# Altium schematic sheet 3. Latching Relays.SchDoc("3. Latching Relays")
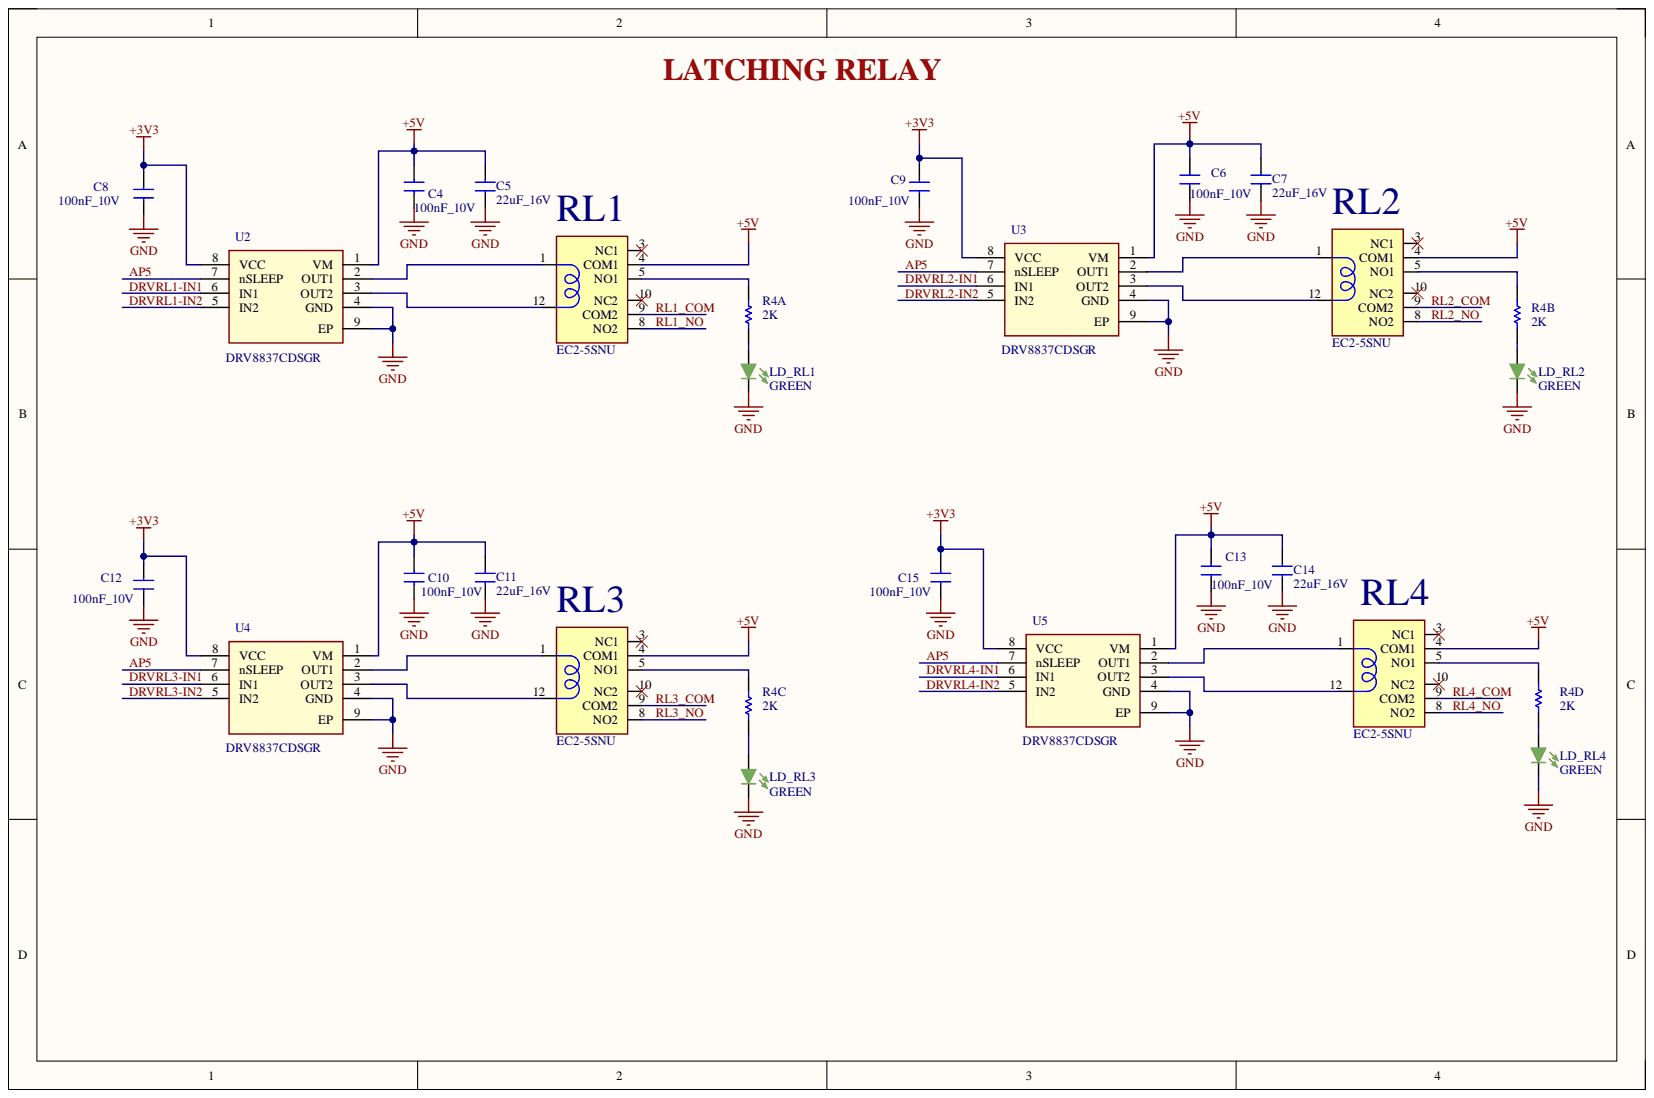
[diagram p0: 3. Latching Relays.SchDoc]

## Parts
C4  100nF_10V  [CN-SMD-0402]
C5  22uF_16V  [C0603]
C6  100nF_10V  [CN-SMD-0402]
C7  22uF_16V  [C0603]
C8  100nF_10V  [CN-SMD-0402]
C9  100nF_10V  [CN-SMD-0402]
C10  100nF_10V  [CN-SMD-0402]
C11  22uF_16V  [C0603]
C12  100nF_10V  [CN-SMD-0402]
C13  100nF_10V  [CN-SMD-0402]
C14  22uF_16V  [C0603]
C15  100nF_10V  [CN-SMD-0402]
LD_RL1  GREEN  [LED0603_GREEN]
LD_RL2  GREEN  [LED0603_GREEN]
LD_RL3  GREEN  [LED0603_GREEN]
LD_RL4  GREEN  [LED0603_GREEN]
R4  2K  [CAC-50P200X100-8M_10K]  (parts A,B,C,D)
RL1  EC2-5SNU  [EC2-5SNU]
RL2  EC2-5SNU  [EC2-5SNU]
RL3  EC2-5SNU  [EC2-5SNU]
RL4  EC2-5SNU  [EC2-5SNU]
U2  DRV8837CDSGR  [WSON-8]
U3  DRV8837CDSGR  [WSON-8]
U4  DRV8837CDSGR  [WSON-8]
U5  DRV8837CDSGR  [WSON-8]

## Nets
+3V3: C8.2 C9.2 C12.2 C15.2 U2.8 U3.8 U4.8 U5.8
+5V: C4.2 C5.2 C6.2 C7.2 C10.2 C11.2 C13.2 C14.2 RL1.4 RL2.4 RL3.4 RL4.4 U2.1 U3.1 U4.1 U5.1
GND: C4.1 C5.1 C6.1 C7.1 C8.1 C9.1 C10.1 C11.1 C12.1 C13.1 C14.1 C15.1 LD_RL1.K LD_RL2.K LD_RL3.K LD_RL4.K U2.4 U2.9 U3.4 U3.9 U4.4 U4.9 U5.4 U5.9
AP5: U2.7 U3.7 U4.7 U5.7
DRVRL1-IN1: U2.6
DRVRL1-IN2: U2.5
DRVRL2-IN1: U3.6
DRVRL2-IN2: U3.5
DRVRL3-IN1: U4.6
DRVRL3-IN2: U4.5
DRVRL4-IN1: U5.6
DRVRL4-IN2: U5.5
RL1_COM: RL1.9
RL1_NO: RL1.8
RL2_COM: RL2.9
RL2_NO: RL2.8
RL3_COM: RL3.9
RL3_NO: RL3.8
RL4_COM: RL4.9
RL4_NO: RL4.8
NetLD_RL1_A: LD_RL1.A R4.1
NetLD_RL2_A: LD_RL2.A R4.2
NetLD_RL3_A: LD_RL3.A R4.3
NetLD_RL4_A: LD_RL4.A R4.4
NetR4_5: R4.5 RL4.5
NetR4_6: R4.6 RL3.5
NetR4_7: R4.7 RL2.5
NetR4_8: R4.8 RL1.5
NetRL1_1: RL1.1 U2.2
NetRL1_12: RL1.12 U2.3
NetRL2_1: RL2.1 U3.2
NetRL2_12: RL2.12 U3.3
NetRL3_1: RL3.1 U4.2
NetRL3_12: RL3.12 U4.3
NetRL4_1: RL4.1 U5.2
NetRL4_12: RL4.12 U5.3

Not connected: RL1 3 10; RL2 3 10; RL3 3 10; RL4 3 10

## Library data of the parts
C4 C6 C8-C10 C12 C13 C15 = CL05B104KP5NNNC: 10V 100nF X7R ±10% 0402 Multilayer Ceramic Capacitors MLCC - SMD/SMT ROHS; Component Kind: Standard; LCSC #: C133086; Library Name: Bokra.SCHLIB
C5 C7 C11 C14 = GRM188C61C226ME01J: CAP CER 22UF 16V X5S 0603; Component Kind: Standard; Library Name: Bokra.SCHLIB
LD_RL1-LD_RL4 = LED0603_GREEN: LED GREEN CLEAR SMD; Manufacturer: XINGLIGHT; Manufacturer Part Number: XL-1608SYGC-06; Dominant Wavelength: 571nm; Forward Current: 30mA; Forward Voltage: 2V; Lens Color: Colorless; Lens Style: Rectangular,Colorless; Luminous Intensity: 35mcd; Max Power Dissipation: 75mW; Number of Elements: 1; Reverse Voltage: 5V; Test Current: 20mA; Viewing Angle: 130°; Wavelength: 574nm; Case Code (Imperial): 0603; Case Code (Metric): 1608; Case/Package: 0603; Category: LEDs; Color: Green; Component Kind: Standard; ComponentLink1Description: https://datasheet.ciiva.com/2109/ltst-c191kgkt-2109973.pdf?src-supplier=Digi-Key; ComponentLink1URL: https://datasheet.ciiva.com/2109/ltst-c191kgkt-2109973.pdf?src-supplier=Digi-Key; Depth: 0.8mm; Height: 0.55mm; Illumination Color: Green; Lead Free: Lead Free; Length: 1.6mm; Lens Transparency: Clear; Library Name: Schematic_Lib.SchLib; Max Operating Temperature: 85°C; Min Operating Temperature: -55°C; Mount: Surface Mount; Number of LEDs: 1; Number of Pins: 2; Package Quantity: 1; Packaging: Tape and Reel; Power Dissipation: 75mW; Power Rating: 75mW; Pricing 1: 1=0.26000, 10=0.14100, 100=0.06560, 500=0.06060, 1000=0.04747, 2000=0.04646 (USD); Radiation Hardening: No; RoHS: Yes; RoHS Compliant: Yes; Stock 1: 910352; Supplier 1: LCSC; Supplier Part Number 1: C965805; Width: 0.8mm
R4 = EXB-28V202JX: RES ARRAY 4 RES 2K OHM 0804; Component Kind: Standard; LCSC #: C2654103; Value: EXB-28V202JX; Library Name: Bokra.SCHLIB
RL1-RL4 = EC2-5SNU: RELAY GENERAL PURPOSE DPDT 2A 5V; MF: KEMET; Component Kind: Standard; MP: EC2-5SNU; Value: EC2-5SNU; Library Name: Bokra.SCHLIB
U2-U5 = DRV8837CDSGR: IC HALF-BRIDGE DRVR PWM 8WSON; Manufacturer: Texas Instruments; Component Kind: Standard; Value: DRV8837CDSGR; Library Name: Bokra.SCHLIB
